# Altium schematic sheet MCU.SchDoc(MCU)
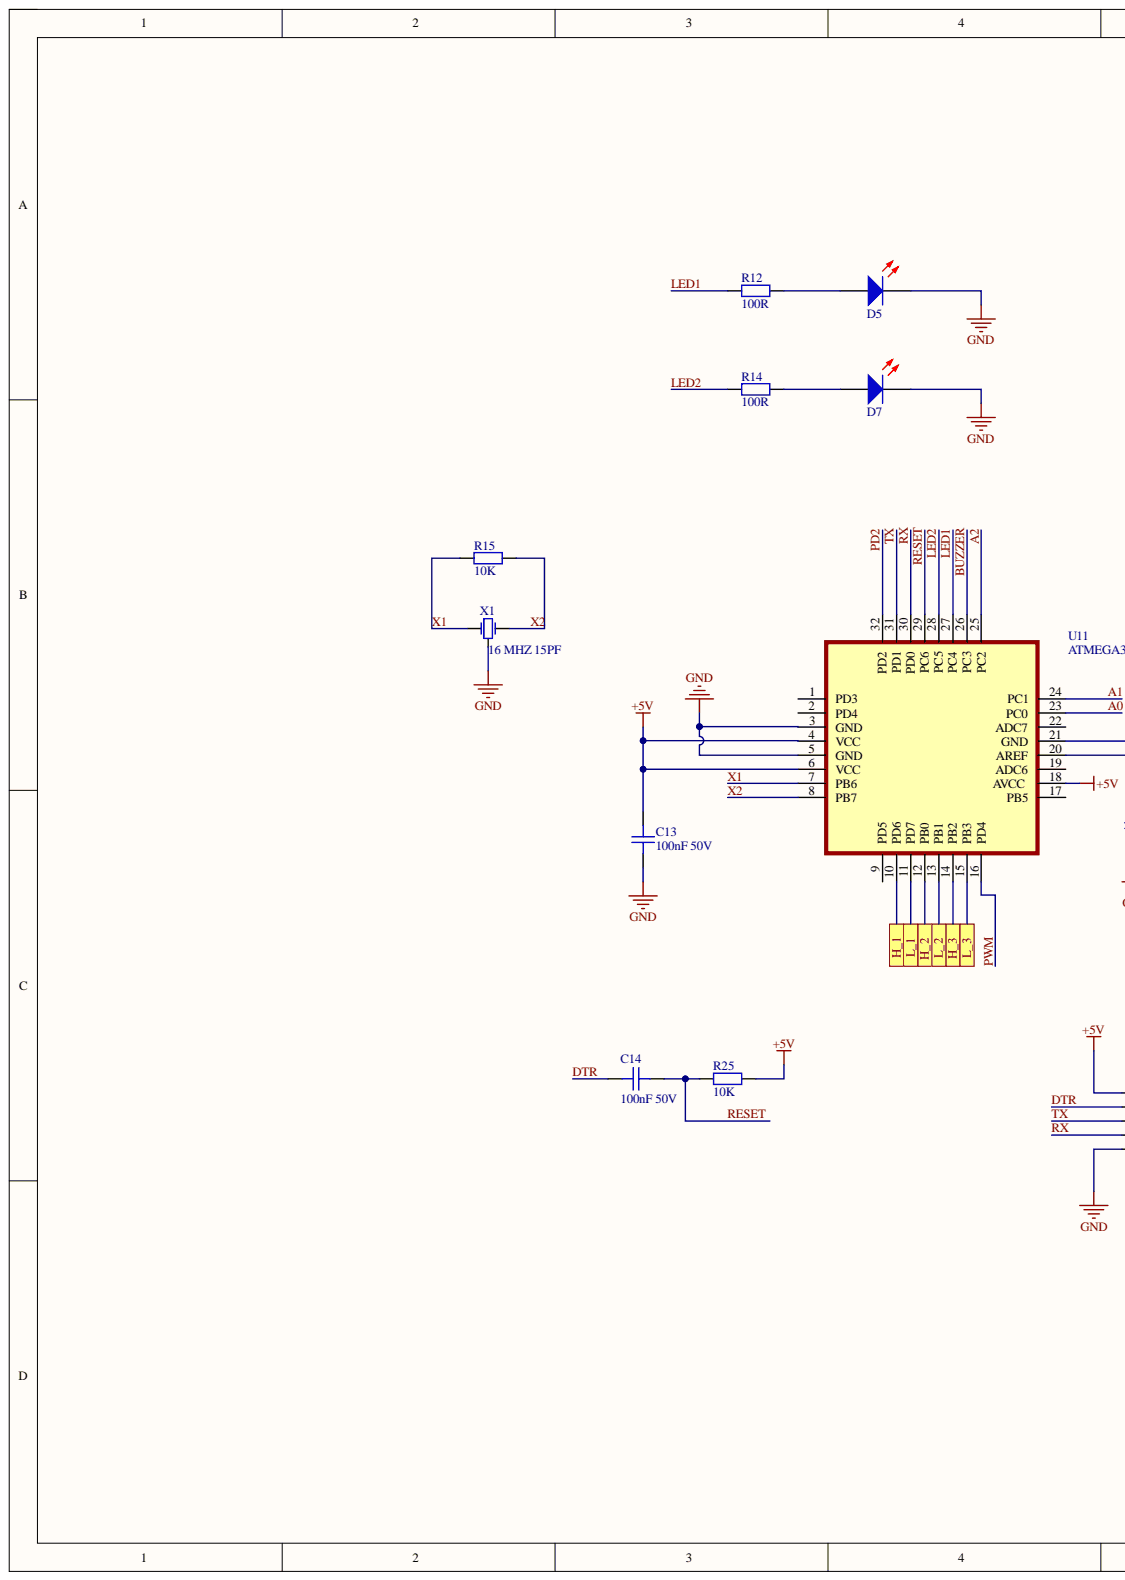
[diagram p0: MCU.SchDoc, left]
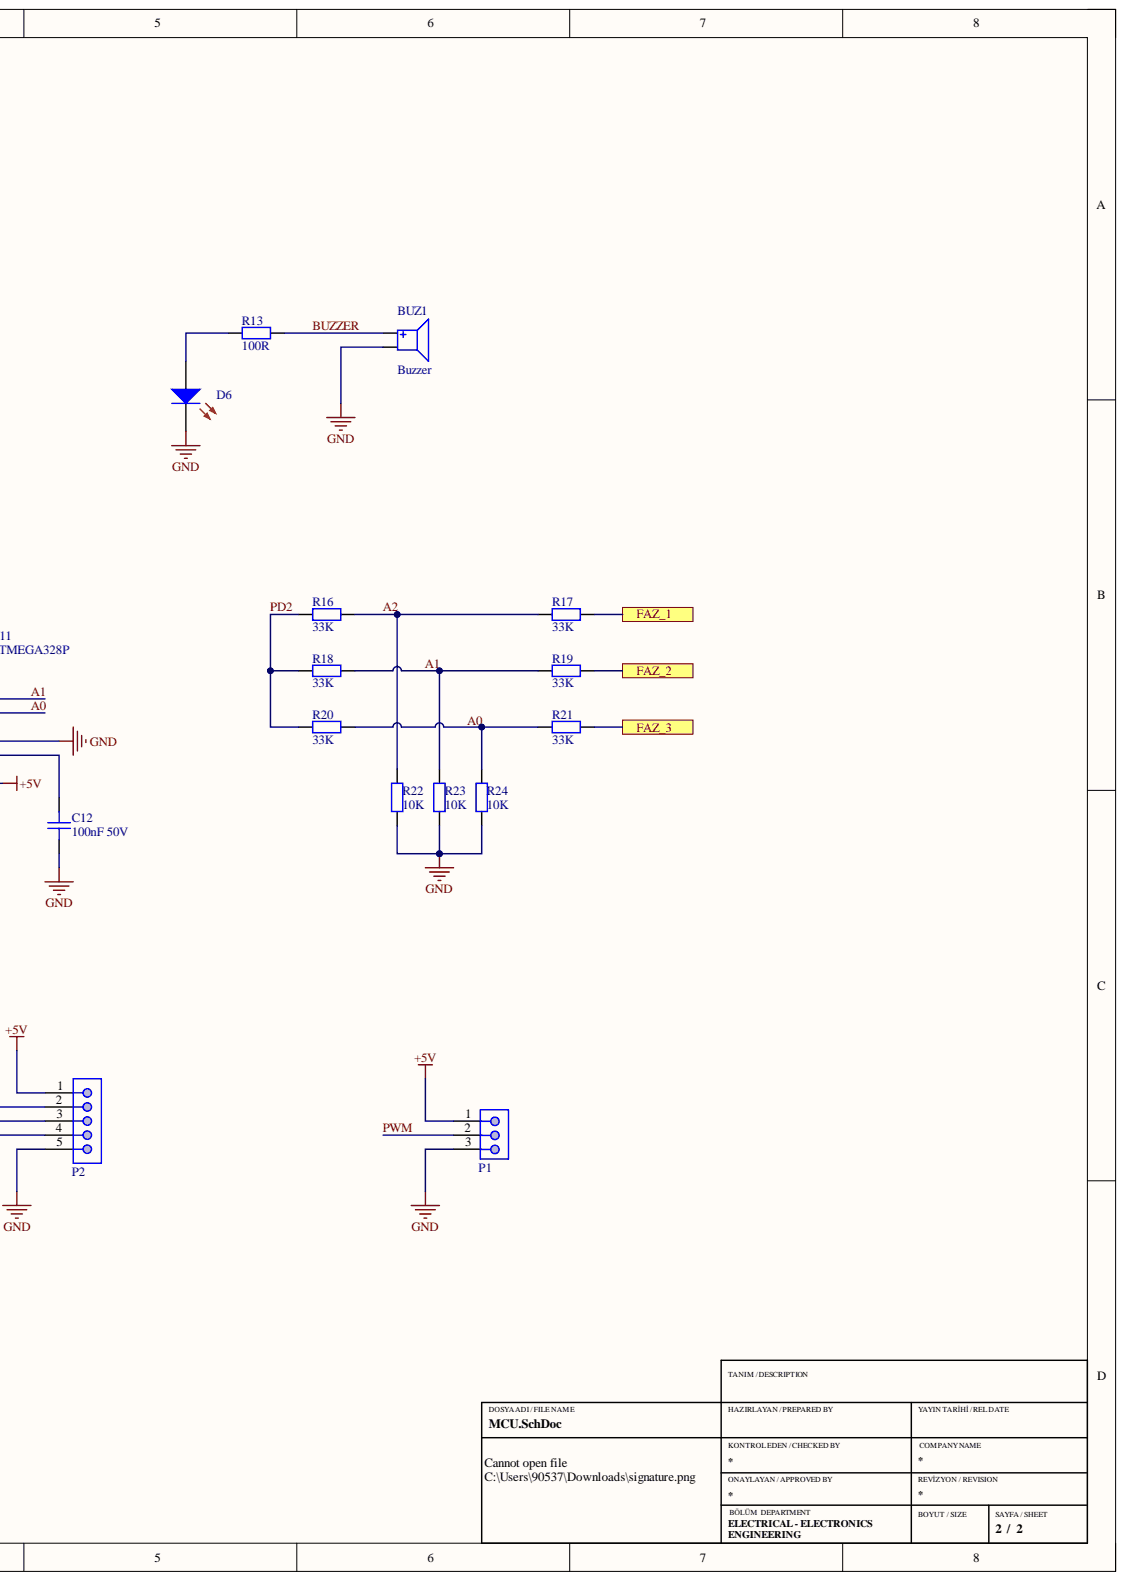
[diagram p1: MCU.SchDoc, right]

## Parts
BUZ1  Cins: Buzzer  [BUZ: CMT-7525]  (hidden comment: BUZZER MAGN 3.6V 7.5X7.5MM SMD)
C12  CAP CER 0.1UF 50V X7R 0805  [CAP 0805/2012]  (value not drawn)
C13  CAP CER 0.1UF 50V X7R 0805  [CAP 0805/2012]  (value not drawn)
C14  Deger: 100nF 50V  [CAP 0805/2012]  (hidden comment: CAP CER 0.1UF 50V X7R 0805)
D5  Red 645nm LED Indication - Discrete 1.8V 0805  [SMD: LED 0805/2012 RED]  (value not drawn)
D6  Red 645nm LED Indication - Discrete 1.8V 0805  [SMD: LED 0805/2012 RED]  (value not drawn)
D7  Red 645nm LED Indication - Discrete 1.8V 0805  [SMD: LED 0805/2012 RED]  (value not drawn)
P1  WR-PHD 2.54 mm Angled Socket Header  [PWM_CONN]  (value not drawn)
P2  WR-PHD 2.54 mm Angled Socket Header  [TTL_CONN_5]  (value not drawn)
R12  Deger: 100R  [RES_0805]  (hidden comment: RES SMD 100 OHM 1% 1/8W 0805)
R13  Deger: 100R  [RES_0805]  (hidden comment: RES SMD 100 OHM 1% 1/8W 0805)
R14  Deger: 100R  [RES_0805]  (hidden comment: RES SMD 100 OHM 1% 1/8W 0805)
R15  Deger: 10K  [RES_0805]  (hidden comment: RES SMD 10K OHM 1% 1/8W 0805)
R16  Deger: 33K  [RES_0805]  (hidden comment: RES SMD 33K OHM 1% 1/8W 0805)
R17  Deger: 33K  [RES_0805]  (hidden comment: RES SMD 33K OHM 1% 1/8W 0805)
R18  Deger: 33K  [RES_0805]  (hidden comment: RES SMD 33K OHM 1% 1/8W 0805)
R19  Deger: 33K  [RES_0805]  (hidden comment: RES SMD 33K OHM 1% 1/8W 0805)
R20  Deger: 33K  [RES_0805]  (hidden comment: RES SMD 33K OHM 1% 1/8W 0805)
R21  Deger: 33K  [RES_0805]  (hidden comment: RES SMD 33K OHM 1% 1/8W 0805)
R22  Deger: 10K  [RES_0805]  (hidden comment: RES SMD 10K OHM 1% 1/8W 0805)
R23  Deger: 10K  [RES_0805]  (hidden comment: RES SMD 10K OHM 1% 1/8W 0805)
R24  Deger: 10K  [RES_0805]  (hidden comment: RES SMD 10K OHM 1% 1/8W 0805)
R25  Deger: 10K  [RES_0805]  (hidden comment: RES SMD 10K OHM 1% 1/8W 0805)
U11  IC MCU 8BIT 32KB FLASH 32TQFP  [TQFP32]  (value not drawn)
X1  Deger: 16 MHZ 15PF  [CRYSTAL_16MHZ(CTSE16)]  (hidden comment: CERAMIC RES 16.0000MHZ 15PF SMD)

## Ports
FAZ_1, FAZ_2, FAZ_3, H_1, H_2, H_3, L_1, L_2, L_3

## Nets
+5V: C13.2 P1.1 P2.1 R25.2 U11.4(VCC) U11.6(VCC) U11.18(AVCC)
GND: BUZ1.2 C12.1 C13.1 D5.2 D6.2 D7.2 P1.3 P2.5 R22.1 R23.1 R24.1 U11.3(GND) U11.5(GND) U11.21(GND) X1.2
A0: R20.2 R21.1 R24.2 U11.23(PC0)
A1: R18.2 R19.1 R23.2 U11.24(PC1)
A2: R16.2 R17.1 R22.2 U11.25(PC2)
BUZZER: BUZ1.1 R13.2 U11.26(PC3)
DTR: C14.1 P2.2
FAZ_1: R17.2 ; port FAZ_1
FAZ_2: R19.2 ; port FAZ_2
FAZ_3: R21.2 ; port FAZ_3
H_1: U11.10(PD6) ; port H_1
H_2: U11.12(PB0) ; port H_2
H_3: U11.14(PB2) ; port H_3
L_1: U11.11(PD7) ; port L_1
L_2: U11.13(PB1) ; port L_2
L_3: U11.15(PB3) ; port L_3
LED1: R12.1 U11.27(PC4)
LED2: R14.1 U11.28(PC5)
PD2: R16.1 R18.1 R20.1 U11.32(PD2)
PWM: P1.2 U11.16(PD4)
RESET: C14.2 R25.1 U11.29(PC6)
RX: P2.4 U11.30(PD0)
TX: P2.3 U11.31(PD1)
X1: R15.1 U11.7(PB6) X1.1
X2: R15.2 U11.8(PB7) X1.3
NetC12_2: C12.2 U11.20(AREF)
NetD5_1: D5.1 R12.2
NetD6_1: D6.1 R13.1
NetD7_1: D7.1 R14.2

Not connected: U11 1(PD3) 2(PD4) 9(PD5) 17(PB5) 19(ADC6) 22(ADC7)

## Library data of the parts
BUZ1 = Buzzer(CMT-7525-80-SMT-TR): Buzzers Transducer, Externally Driven Magnetic 3.6V 100mA 2.73kHz 80dB @ 3.6V, 10cm Surface Mount Solder Pads; +Sicaklik: +85; -Sicaklik: -40; Kılıf: Nonstandard; Component Kind: Standard; Deger: Buzzer; Lehim Yöntemi: SMD; MSL: 1 (Unlimited); Uretici Kodu: CMT-7525-80-SMT-TR; Library Name: PROJE.SchLib
C12-C14 = 100nF 50V 0805: 0.1µF ±10% 50V Ceramic Capacitor X7R 0805 (2012 Metric); +Sicaklik: +125; -Sicaklik: -55; Cins: Kondansator; Kılıf: 0805; Lehim Yöntemi: SMD; Uretici Kodu: CL21B104KBCNNNC; Component Kind: Standard; MSL: 1 (Unlimited); Library Name: PROJE.SchLib
  C12 C13: Deger: 100nF 50V
D5-D7 = LED_Kırmızı: LED RED DIFFUSED 0805 SMD; +Sicaklik: +125; -Sicaklik: -40; Deger: KIRMIZI LED; Kılıf: 0805; Uretici Kodu: LH R974-LP-1; Cins: LED; Component Kind: Standard; Lehim Yöntemi: SMD; MSL: 1 (Unlimited); Library Name: PROJE.SchLib
P1 = HDR(1X3): +Sicaklik: +105; -Sicaklik: -40; Cins: Konnektor; Kılıf: 3POS 2.54MM; Lehim Yöntemi: MANUEL; Uretici Kodu: 613003143121; Component Kind: Standard; Description: WR-PHD 2.54 mm Angled Socket Header; MSL: 1 (Unlimited); Library Name: PROJE.SchLib
P2 = HDR(1X5): +Sicaklik: +105; -Sicaklik: -40; Cins: Konnektor; Kılıf: 5POS 2.54MM; Lehim Yöntemi: MANUEL; Uretici Kodu: 613005143121; Component Kind: Standard; Description: WR-PHD 2.54 mm Angled Socket Header; MSL: 1 (Unlimited); Library Name: PROJE.SchLib
R12-R14 = 100R 0805: 100 Ohms ±1% 0.125W, 1/8W Chip Resistor 0805 (2012 Metric) Moisture Resistant Thick Film; +Sicaklik: +155; -Sicaklik: -55; Cins: Direnc; Kılıf: 0805; Uretici Kodu: RC0805FR-07100RL; Component Kind: Standard; Lehim Yöntemi: SMD; MSL: 1 (Unlimited); Library Name: PROJE.SchLib
R15 R22-R25 = 10K 0805: 10 kOhms ±1% 0.125W, 1/8W Chip Resistor 0805 (2012 Metric) Moisture Resistant Thick Film; +Sicaklik: +155; -Sicaklik: -55; Cins: Direnc; Kılıf: 0805; Uretici Kodu: RC0805FR-0710KL; Component Kind: Standard; Lehim Yöntemi: SMD; MSL: 1 (Unlimited); Library Name: PROJE.SchLib
R16-R21 = 33K 0805: 33 kOhms ±1% 0.125W, 1/8W Chip Resistor 0805 (2012 Metric) Moisture Resistant Thick Film; +Sicaklik: +155; -Sicaklik: -55; Cins: Direnc; Kılıf: 0805; Uretici Kodu: RC0805FR-0733KL; Component Kind: Standard; Lehim Yöntemi: SMD; MSL: 1 (Unlimited); Library Name: PROJE.SchLib
U11 = ATMEGA328P: AVR AVR® ATmega Microcontroller IC 8-Bit 20MHz 32KB (16K x 16) FLASH 32-TQFP (7x7); +Sicaklik: +85; -Sicaklik: -40; Lehim Yöntemi: SMD; Uretici Kodu: RC0805FR-07100RL; Cins: IC; Component Kind: Standard; Deger: ATMEGA328P; Kılıf: 32-TQFP; MSL: 3 (168 Hours); Library Name: PROJE.SchLib
X1 = CRYSTAL 16.0000MHZ(CSTCE16M0V53-R0): 16MHz Ceramic Resonator Built in Capacitor 15pF ±0.3%  -20°C ~ 80°C Surface Mount; +Sicaklik: +80; -Sicaklik: -20; Cins: Kristal; Kılıf: 3-SMD; Component Kind: Standard; Lehim Yöntemi: SMD; MSL: 1 (Unlimited); Uretici Kodu: CSTCE16M0V53-R0; Library Name: PROJE.SchLib
